# Altium schematic sheet Half_Bridge.SchDoc
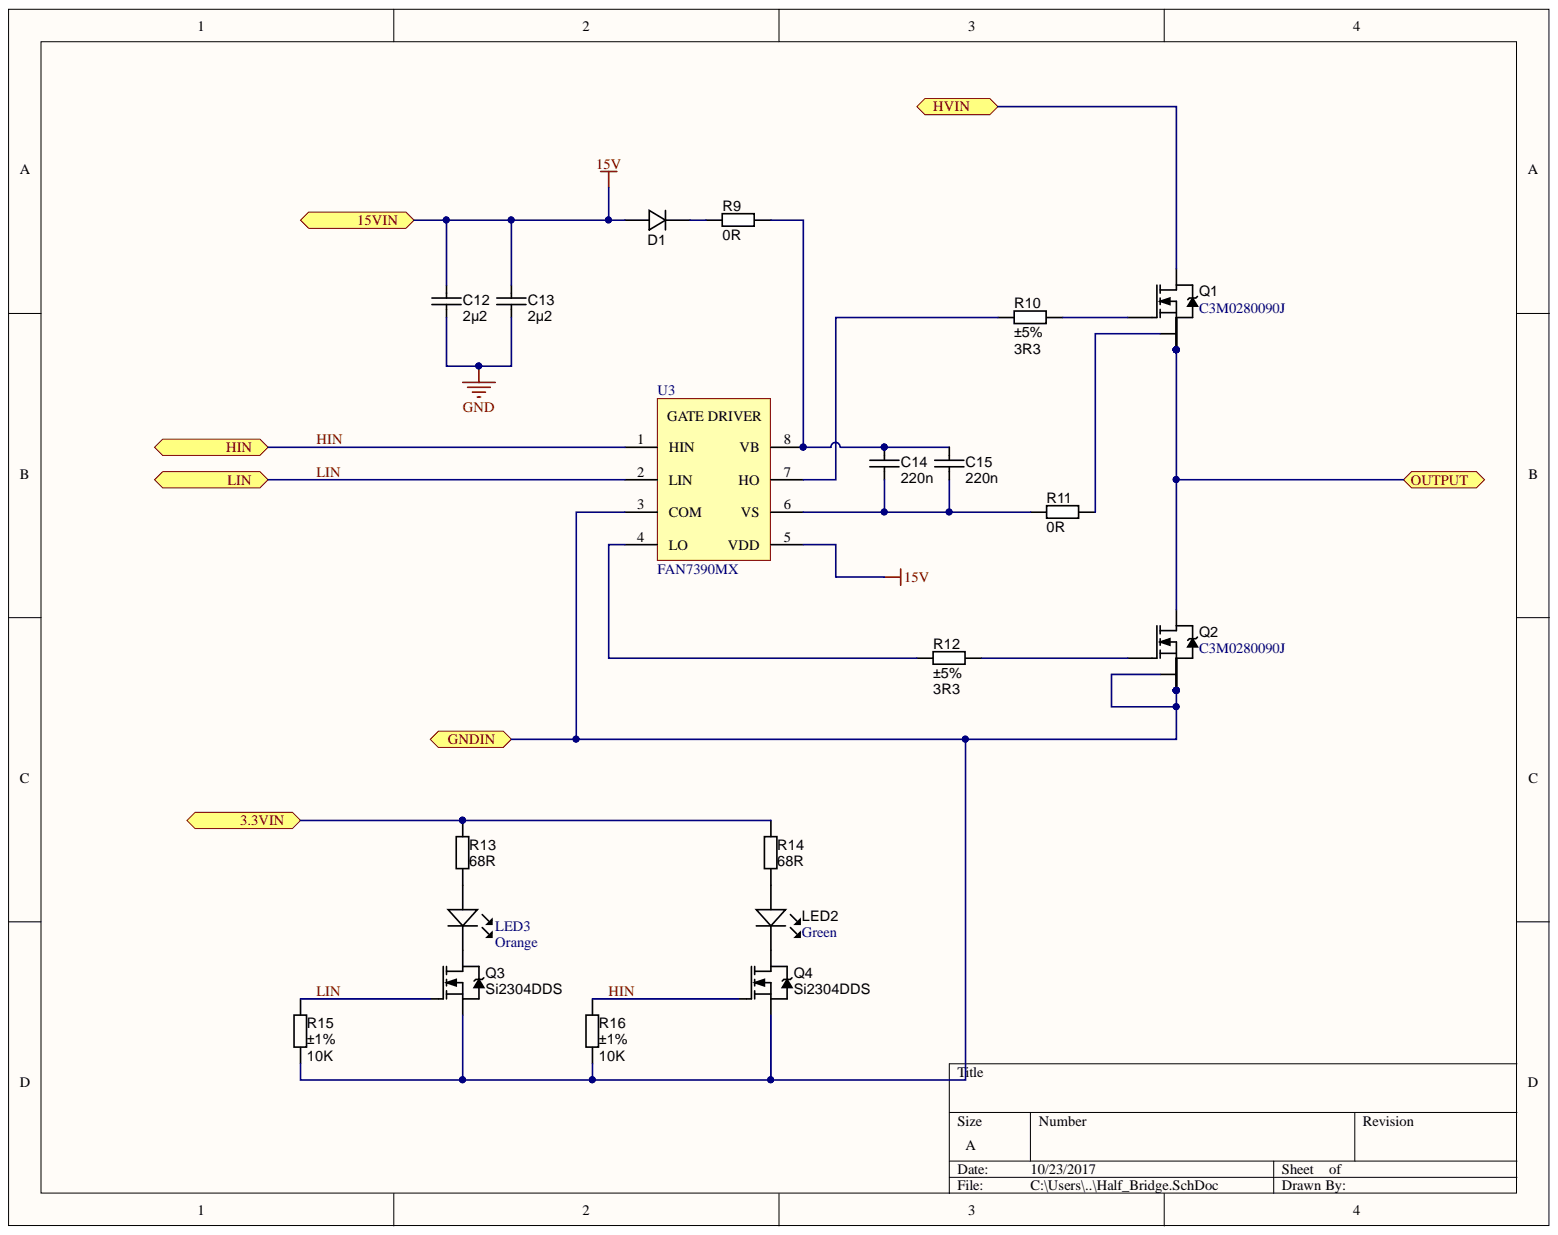
[diagram p0: Half_Bridge.SchDoc]

## Parts
C12  value 2µ2  [CAPC3216X180N]  (hidden comment: approved sub OK)
C13  value 2µ2  [CAPC3216X180N]  (hidden comment: approved sub OK)
C14  value 220n  [CAPC1632X07N]  (hidden comment: approved sub OK)
C15  value 220n  [CAPC1632X07N]  (hidden comment: approved sub OK)
D1  FR10M-TP  [DO-214AB]  (value not drawn)
LED2  Color: Green  [LED-0603-GREEN]  (hidden comment: APT1608CGCK)
LED3  Color: Orange  [LED-0603-AMBER]  (hidden comment: LO L296-Q2S1-24-Z)
Q1  Manufacturer Part Number: C3M0280090J  [DPAK127P1618X457-8N]  (hidden comment: C3M0065090D)
Q2  Manufacturer Part Number: C3M0280090J  [DPAK127P1618X457-8N]  (hidden comment: C3M0065090D)
Q3  Si2304DDS  [SOT23-3]
Q4  Si2304DDS  [SOT23-3]
R9  value 0R  [RESC2012N_ZERO]  (hidden comment: Jumper)
R10  value 3R3  Tolerance: ±5%  [RESC2012X70N]  (hidden comment: Thick Film)
R11  value 0R  [RESC2012N_ZERO]  (hidden comment: Jumper)
R12  value 3R3  Tolerance: ±5%  [RESC2012X70N]  (hidden comment: Thick Film)
R13  value 68R  [RESC1608X55N]  (hidden comment: Thick Film)
R14  value 68R  [RESC1608X55N]  (hidden comment: Thick Film)
R15  value 10K  Tolerance: ±1%  [RESC1005X40N]  (hidden comment: Thick Film)
R16  value 10K  Tolerance: ±1%  [RESC1005X40N]  (hidden comment: Thick Film)
U3  FAN7390MX  [SOIC127P600X175-8AN]

## Ports
3.3VIN, 15VIN, GNDIN, HIN, HVIN, LIN, OUTPUT

## Nets
GND: C12.2 C13.2
3.3VIN: R13.1 R14.1 ; port 3.3VIN
15V: C12.1 C13.1 D1.A U3.5(VDD) ; port 15VIN
GNDIN: Q2.2 Q2.3 Q2.4 Q2.5 Q2.6 Q2.7 Q3.2 Q4.2 R15.2 R16.2 U3.3(COM) ; port GNDIN
HIN: Q4.1 R16.1 U3.1(HIN) ; port HIN
HVIN: Q1.8 ; port HVIN
LIN: Q3.1 R15.1 U3.2(LIN) ; port LIN
OUTPUT: Q1.3 Q1.4 Q1.5 Q1.6 Q1.7 Q2.8 ; port OUTPUT
NetC14_1: C14.1 C15.1 R9.2 U3.8(VB)
NetC14_2: C14.2 C15.2 R11.1 U3.6(VS)
NetD1_K: D1.K R9.1
NetLED2_A: LED2.A R14.2
NetLED2_K: LED2.K Q4.3
NetLED3_A: LED3.A R13.2
NetLED3_K: LED3.K Q3.3
NetQ1_1: Q1.1 R10.2
NetQ1_2: Q1.2 R11.2
NetQ2_1: Q2.1 R12.2
NetR10_1: R10.1 U3.7(HO)
NetR12_1: R12.1 U3.4(LO)

## Library data of the parts
C12 C13 = CAPC_2u2_50V_1206: Capacitor SMD Cer 2.2uF 10% 50V X7R 1206; SCDType: undef; Component Kind: Standard; Library Name: PBL - Capacitors.SCHLIB; Supplier 1: Digi-Key; Supplier Part Number 1: 1276-1291-1-ND; Tolerance: ±10%
C14 C15 = CAPC_220n_0612_X7R_25V_10%: Capacitor SMD Cer 220nF 10% 25V X7R 0612; Manufacturer: AVX Corporation; Manufacturer Part Number: 06123C224KAT2A; Tolerance: ±20%; Applications: Bypass, Decoupling; Category: Capacitors; Component Kind: Standard; ComponentLink1Description: http://datasheets.avx.com/licc.pdf; ComponentLink1URL: http://datasheets.avx.com/licc.pdf; Features: Low ESL (Reverse Geometry); Height - Seated (Max): -; Lead Spacing: -; Lead Style: -; Library Name: PBL - Capacitors.SCHLIB; Mounting Type: Surface Mount, MLCC; Operating Temperature: -55°C ~ 125°C; Package / Case: 0612 (1632 Metric); Packaging: Cut Tape (CT); Part Status: Active; Pricing 1: 1=1.73, 10=1.366, 100=1.0247, 500=0.77418, 1000=0.6831 (USD); Ratings: -; RoHS: RoHS Compliant; Size / Dimension: 0.063" L x 0.126" W (1.60mm x 3.20mm); Stock 1: 3680; Supplier 1: Digi-Key; Supplier Part Number 1: 478-2902-1-ND; Temperature Coefficient: X7R; Thickness (Max): 0.050" (1.27mm); Voltage - Rated: 25V
D1 = DIODE_1000V_10A_SMC_FR10M-TP: Diode Standard 1000V 10A Surface Mount DO-214AB, (SMC); Manufacturer: Micro Commercial Co; Current - Reverse Leakage @ Vr: 10µA @ 1000V; Speed: Fast Recovery =< 500ns, > 200mA (Io); Voltage - Forward (Vf) (Max) @ If: 1.3V @ 10A; Capacitance @ Vr, F: -; Category: Discrete Semiconductor Products; Component Kind: Standard; ComponentLink1Description: http://www.mccsemi.com/up_pdf/FR10A-FR10M(HSMC).pdf; ComponentLink1URL: http://www.mccsemi.com/up_pdf/FR10A-FR10M(HSMC).pdf; Current - Average Rectified (Io): 10A; Diode Type: Standard; Library Name: PBL - Diodes.SCHLIB; Manufacturer Part Number: FR10M-TP; Mounting Type: Surface Mount; Operating Temperature - Junction: -55°C ~ 150°C; Package / Case: DO-214AB, SMC; Packaging: Cut Tape (CT); Part Status: Active; Pricing 1: 1=0.78, 10=0.681, 25=0.6036, 100=0.5256, 250=0.45748, 500=0.38934 (USD); RoHS: RoHS Compliant; Stock 1: 6; Supplier 1: Digi-Key; Supplier Device Package: DO-214AB, (SMC); Supplier Part Number 1: FR10M-TPMSCT-ND
LED2 = LED_SMD_Green_0603_50mcd: Green 570nm LED Indication - Discrete 2.1V 0603 (1608 Metric); Manufacturer: Kingbright; Current - Test: 20mA; Lens Color: Colorless; Lens Style/Size: Rectangle with Flat Top, 1.20mm x 0.80mm; Lens Transparency: Clear; Millicandela Rating: 50mcd; Viewing Angle: 120°; Wavelength - Peak: 574nm; Category: Optoelectronics; Component Kind: Standard; ComponentLink1Description: http://www.kingbrightusa.com/images/catalog/SPEC/APT1608CGCK.pdf; ComponentLink1URL: http://www.kingbrightusa.com/images/catalog/SPEC/APT1608CGCK.pdf; Features: -; Height (Max): 0.75mm; Library Name: PBL - Light Sources.SCHLIB; Manufacturer Part Number: APT1608CGCK; Mounting Type: Surface Mount; Package / Case: 0603 (1608 Metric); Packaging: Cut Tape (CT); Part Status: Active; Pricing 1: 1=0.47, 10=0.337, 25=0.258, 100=0.1683, 250=0.12344, 500=0.10472, 1000=0.08602 (USD); RoHS: RoHS Compliant; Size / Dimension: 1.60mm L x 0.80mm W; Stock 1: 896844; Supplier 1: Digi-Key; Supplier Device Package: Chip LED; Supplier Part Number 1: 754-1116-1-ND; Voltage - Forward (Vf) (Typ): 2.1V; Wavelength - Dominant: 570nm
LED3 = LED_0603_Orange: LED ORANGE DIFFUSED 0603 SMD; Manufacturer: OSRAM Opto Semiconductors Inc.; Current - Test: 20mA; Lens Color: White; Lens Style/Size: Rectangle with Flat Top, 1.30mm x 0.80mm; Millicandela Rating: 157mcd; Viewing Angle: 160°; Voltage - Forward (Vf) (Typ): 2V; Wavelength - Dominant: 605nm; Category: Optoelectronics; Component Kind: Standard; ComponentLink1Description: http://www.osram-os.com/Graphics/XPic9/00077122_0.pdf; ComponentLink1URL: http://www.osram-os.com/Graphics/XPic9/00077122_0.pdf; Features: -; Height (Max): 0.65mm; Lens Transparency: Diffused; Library Name: PBL - Light Sources.SCHLIB; Manufacturer Part Number: LO L296-Q2S1-24-Z; Mounting Type: Surface Mount; Package / Case: 0603 (1608 Metric); Packaging: Cut Tape (CT); Part Status: Active; Pricing 1: 1=0.34, 10=0.245, 25=0.2004, 100=0.1603, 250=0.13524, 500=0.11522, 1000=0.09017, 2500=0.08349 (USD); RoHS: RoHS Compliant; Size / Dimension: 1.70mm L x 0.80mm W; Stock 1: 4435; Supplier 1: Digi-Key; Supplier Part Number 1: 475-2739-1-ND; Wavelength - Peak: -
Q1 Q2 = SiC_N-CHAN_900V_11A_C3M0280090J: N-Channel 36A (Tc) 125W (Tc) Through Hole TO-247-3; Manufacturer: Cree/Wolfspeed; Current - Continuous Drain (Id) @ 25°C: 11A (Tc); Drive Voltage (Max Rds On,  Min Rds On): 15V; Gate Charge (Qg) (Max) @ Vgs: 9.5nC @ 15V; Input Capacitance (Ciss) (Max) @ Vds: 150pF @ 600V; Power Dissipation (Max): 50W (Tc); Rds On (Max) @ Id, Vgs: 360 mOhm @ 7.5A, 15V; Vgs (Max): +18V, -8V; Vgs(th) (Max) @ Id: 3.5V @ 1.2mA; Category: Discrete Semiconductor Products; Component Kind: Standard; ComponentLink1Description: http://www.wolfspeed.com/media/downloads/835/C3M0280090J.pdf; ComponentLink1URL: http://www.wolfspeed.com/media/downloads/835/C3M0280090J.pdf; FET Feature: -; FET Type: N-Channel; Library Name: PBL - Transistors.SCHLIB; Mounting Type: Surface Mount; Operating Temperature: -55°C ~ 150°C (TJ); Package / Case: TO-263-8, D²Pak (7 Leads + Tab), TO-263CA; Packaging: Tube; Part Status: Active; Pricing 1: 1=3.84 (USD); RoHS: RoHS Compliant; Stock 1: 713; Supplier 1: Digi-Key; Supplier Device Package: D2PAK-7; Supplier Part Number 1: C3M0280090J-ND; Technology: SiCFET (Silicon Carbide)
Q3 Q4 = MOSFET_Si2304DDS: MOSFET SMD N-CH 30V 3.6A 1.7W SOT23-3; Material: Metal Oxide; Ratings: 30V; 3.6A; SCDType: source; Component Kind: Standard; Library Name: PBL - Transistors.SCHLIB; Package / Case: SOT23-3; Supplier 1: Digi-Key; Supplier Part Number 1: SI2304DDS-T1-GE3CT-ND
R9 R11 = RES_0R_0805: Resistor SMD chip 0.0 Ohm 0.125W 0805; Manufacturer 1: Samsung Electro-Mechanics America, Inc; Manufacturer Part Number 1: RC2012J000CS; Ratings: 1/8W; SCDType: spec; Component Kind: Standard; ComponentLink1Description: http://www.samsungsem.com/servlet/FileDownload?filename=productcatalog/pdf/Chip_Resistor.pdf; ComponentLink1URL: http://www.samsungsem.com/servlet/FileDownload?filename=productcatalog/pdf/Chip_Resistor.pdf; ComponentLink2Description: http://www.seielect.com/Catalog/SEI-RMCF_RMCP.pdf; ComponentLink2URL: http://www.seielect.com/Catalog/SEI-RMCF_RMCP.pdf; ComponentLink3Description: http://media.digikey.com/pdf/Data%20Sheets/Yageo%20PDFs/RC0805%20Pb%20Free.pdf; ComponentLink3URL: http://media.digikey.com/pdf/Data%20Sheets/Yageo%20PDFs/RC0805%20Pb%20Free.pdf; ComponentLink4Description: http://industrial.panasonic.com/www-cgi/jvcr13pz.cgi?E+PZ+3+AOA0001+ERJ6GEY0R00V+7+WW; ComponentLink4URL: http://industrial.panasonic.com/www-cgi/jvcr13pz.cgi?E+PZ+3+AOA0001+ERJ6GEY0R00V+7+WW; ComponentLink5Description: http://rohmfs.rohm.com/en/products/databook/datasheet/passive/resistor/chip_resistor/mcr_g.pdf; ComponentLink5URL: http://rohmfs.rohm.com/en/products/databook/datasheet/passive/resistor/chip_resistor/mcr_g.pdf; Library Name: PBL - Resistors.SCHLIB; Manufacturer 2: Stackpole Electronics Inc; Manufacturer 3: Yageo; Manufacturer 4: Panasonic Electronic Components; Manufacturer 5: Rohm Semiconductor; Manufacturer Part Number 2: RMCF0805ZT0R00; Manufacturer Part Number 3: RC0805JR-070RL; Manufacturer Part Number 4: ERJ-6GEY0R00V; Manufacturer Part Number 5: MCR10ERTJ000; Package / Case: 0805 (2012 metric); RoHS 1: RoHS Compliant; RoHS 2: RoHS Compliant; RoHS 3: RoHS Compliant; RoHS 4: RoHS Compliant; RoHS 5: RoHS Compliant; Supplier 1: Digi-Key; Supplier 2: Digi-Key; Supplier 3: Digi-Key; Supplier 4: Digi-Key; Supplier 5: Digi-Key; Supplier Part Number 1: 1276-5466-6-ND; Supplier Part Number 2: RMCF0805ZT0R00DKR-ND; Supplier Part Number 3: 311-0.0ARDKR-ND; Supplier Part Number 4: P0.0ADKR-ND; Supplier Part Number 5: RHM0.0CPDKR-ND; Tolerance: N/A
R10 R12 = RES_3R3_0805_5%: Resistor SMD chip 3.3 Ohm 0.5W 0805; Manufacturer: Panasonic Electronic Components; Manufacturer Part Number: ERJ-6DQJ3R0V; Power (Watts): 0.5W, 1/2W; Temperature Coefficient: ±100ppm/°C; Category: Resistors; Component Kind: Standard; ComponentLink1Description: https://industrial.panasonic.com/cdbs/www-data/pdf/RDN0000/AOA0000C313.pdf; ComponentLink1URL: https://industrial.panasonic.com/cdbs/www-data/pdf/RDN0000/AOA0000C313.pdf; Composition: Thick Film; Failure Rate: -; Features: Automotive AEC-Q200, Current Sense; Height - Seated (Max): 0.028" (0.70mm); Library Name: PBL - Resistors.SCHLIB; Operating Temperature: -55°C ~ 155°C; Package / Case: 0805 (2012 Metric); Packaging: Cut Tape (CT); Part Status: Active; Pricing 1: 1=0.23, 10=0.198, 25=0.1444, 50=0.1046, 100=0.0776, 250=0.05952, 500=0.0469, 1000=0.03247 (USD); RoHS: RoHS Compliant; Size / Dimension: 0.079" L x 0.049" W (2.00mm x 1.25mm); Stock 1: 3694; Supplier 1: Digi-Key; Supplier Device Package: 0805; Supplier Part Number 1: P19231CT-ND
R13 R14 = RES_68R_0603_5%: Resistor SMD chip 68 Ohm 0.1W 5% 0603; Manufacturer 1: Yageo; Manufacturer Part Number 1: RC0603JR-0768RL; Ratings: 1/10 W; SCDType: spec; Component Kind: Standard; Library Name: PBL - Resistors.SCHLIB; Material: Thick Film; Package / Case: 0603 (1608 metric); Supplier 1: Digi-Key; Supplier Part Number 1: 311-68GRCT-ND; Tolerance: ±5%
R15 R16 = RES_10K_0402_1%: Resistor SMD chip 10k Ohm 0.063W 1% 0402; Manufacturer 1: Vishay Dale; Manufacturer Part Number 1: CRCW040210K0FKED; Ratings: 1/16 W; SCDType: spec; Component Kind: Standard; ComponentLink1Description: http://www.vishay.com/doc?20035; ComponentLink1URL: http://www.vishay.com/doc?20035; ComponentLink2Description: http://www.yageo.com/documents/recent/PYu-RC0402_51_RoHS_L_6.pdf; ComponentLink2URL: http://www.yageo.com/documents/recent/PYu-RC0402_51_RoHS_L_6.pdf; Library Name: PBL - Resistors.SCHLIB; Manufacturer 2: Yageo; Manufacturer Part Number 2: RC0402FR-0710KL; Package / Case: 0402 (1005 Metric); Supplier 1: Digi-Key; Supplier 2: Digi-Key; Supplier Part Number 1: 541-10.0KLCT-ND; Supplier Part Number 2: 311-10.0KLRCT-ND
U3 = GATE_DRV_2_600V_4.5A: Half-Bridge Gate Driver IC Non-Inverting 8-SOP; Manufacturer: Fairchild/ON Semiconductor; Channel Type: Independent; Current - Peak Output (Source, Sink): 4.5A, 4.5A; Gate Type: IGBT, N-Channel MOSFET; Logic Voltage - VIL, VIH: 1.2V, 2.5V; Rise / Fall Time (Typ): 25ns, 20ns; Voltage - Supply: 10 V ~ 22 V; Category: Integrated Circuits (ICs); Component Kind: Standard; ComponentLink1Description: https://www.fairchildsemi.com/datasheets/FA/FAN7390.pdf; ComponentLink1URL: https://www.fairchildsemi.com/datasheets/FA/FAN7390.pdf; Driven Configuration: Half-Bridge; Input Type: Non-Inverting; Library Name: PBL - Integrated Circuits.SCHLIB; Manufacturer Part Number: FAN7390MX; Mounting Type: Surface Mount; Operating Temperature: -40°C ~ 150°C (TJ); Package / Case: 8-SOIC (0.154", 3.90mm Width); Packaging: Cut Tape (CT); Part Status: Active; Pricing 1: 1=1.79, 10=1.61, 100=1.2944, 500=1.06348, 1000=0.88117 (USD); RoHS: RoHS Compliant; Stock 1: 5064; Supplier 1: Digi-Key; Supplier Device Package: 8-SOP; Supplier Part Number 1: FAN7390MXCT-ND
